# Altium schematic sheet PowerSupply.SchDoc(PowerSupply)
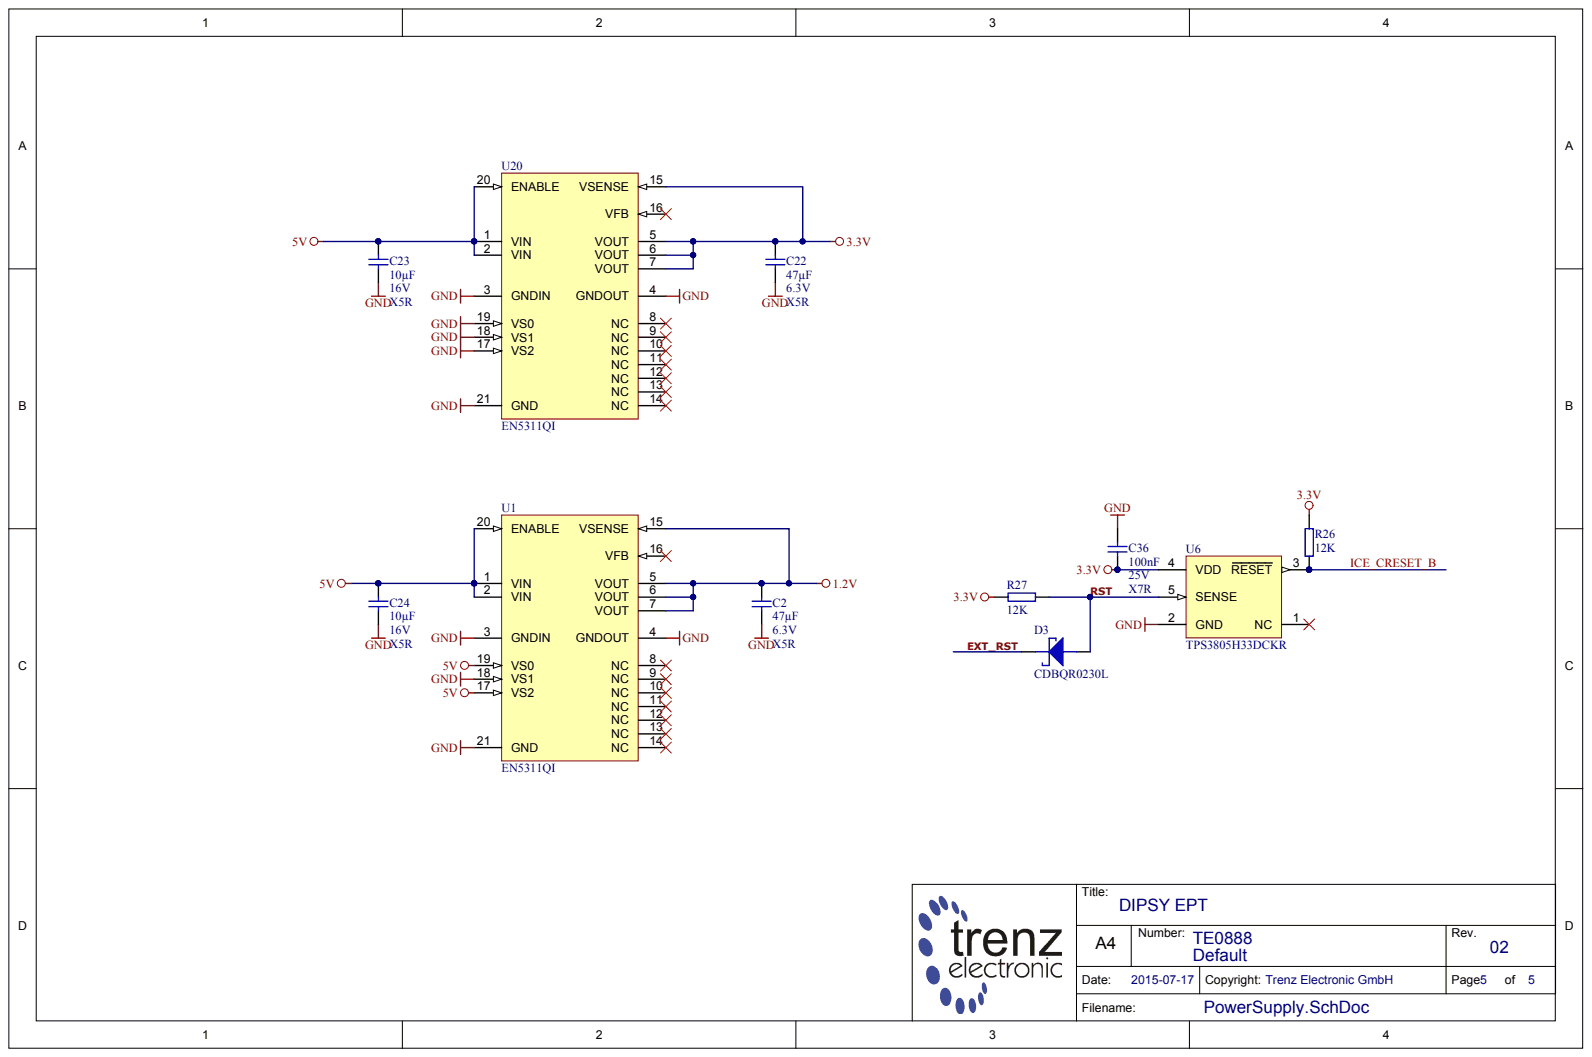
[diagram p0: PowerSupply.SchDoc]

## Parts
C2  47µF  Technology: X5R  Voltage: 6.3V  [CAPC2012X135N]
C22  47µF  Technology: X5R  Voltage: 6.3V  [CAPC2012X135N]
C23  10µF  Technology: X5R  Voltage: 16V  [CAPC1608X90N]
C24  10µF  Technology: X5R  Voltage: 16V  [CAPC1608X90N]
C36  100nF  Voltage: 25V  [CAPC1005X55N]
D3  CDBQR0230L  [DIOC1006X55N]
R26  12K  [RESC1005X40N]
R27  12K  [RESC1005X40N]
U1  EN5311QI  [EN5311QI]
U6  TPS3805H33DCKR  [SOT65P210X110-5N]
U20  EN5311QI  [EN5311QI]

## Nets
1.2V: C2.2 U1.5(VOUT) U1.6(VOUT) U1.7(VOUT) U1.15(VSENSE)
3.3V: C22.2 C36.2 R26.2 R27.2 U6.4(VDD) U20.5(VOUT) U20.6(VOUT) U20.7(VOUT) U20.15(VSENSE)
5V: C23.1 C24.1 U1.1(VIN) U1.2(VIN) U1.17(VS2) U1.19(VS0) U1.20(ENABLE) U20.1(VIN) U20.2(VIN) U20.20(ENABLE)
GND: C2.1 C22.1 C23.2 C24.2 C36.1 U1.3(GNDIN) U1.4(GNDOUT) U1.18(VS1) U1.21(GND) U6.2(GND) U20.3(GNDIN) U20.4(GNDOUT) U20.17(VS2) U20.18(VS1) U20.19(VS0) U20.21(GND)
EXT_RST: D3.1
ICE_CRESET_B: R26.1 U6.3(RESET)
RST: D3.2 R27.1 U6.5(SENSE)

Not connected: U1 8(NC) 9(NC) 10(NC) 11(NC) 12(NC) 13(NC) 14(NC) 16(VFB); U6 1(NC); U20 8(NC) 9(NC) 10(NC) 11(NC) 12(NC) 13(NC) 14(NC) 16(VFB)

## Library data of the parts
C2 C22 = 24718: KON 47µF 6,3V  X5R SMD 20%; Database Table Name: C_2012_0805; Mixed: SMT; Preferred: Yes; Value: 47u; AN: 24718; Case: 2012 (0805); Component Kind: Standard; Library Name: TE.DbLib; Tolerance: 20%
C23 C24 = 25341: KON 10µF 16V X5R SMD 20%; Database Table Name: C_1608_0603; Mixed: SMT; Preferred: Yes; Value: 10u; AN: 25341; Case: 1608 (0603); Component Kind: Standard; Library Name: TE.DbLib; Tolerance: 20%
C36 = 22472: KON 100nF 25V X5R SMD; Database Table Name: C_1005_0402; Mixed: SMT; Preferred: Yes; Value: 100n; AN: 22472; Case: 1005 (0402); Component Kind: Standard; Library Name: TE.DbLib; Technology: X7R
D3 = 26726: Database Table Name: DIODE; Mixed: SMT; Value: 30V; Voltage: 0.2A; AN: 26726; Case: SOD-923F (0402); Component Kind: Standard; Description: CDBQR0230L; Library Name: TE.DbLib; HelpURL: B:\ad\adlib\datasheet\CDBQR0230L
R26 R27 = 22598: WID SMD 12K 1% 0,063W; Database Table Name: R_1005_0402; Mixed: SMT; Preferred: Yes; AN: 22598; Case: 1005 (0402); Component Kind: Standard; Library Name: TE.DbLib; Value: 12K
U1 U20 = 25492: Database Table Name: DCDC; Mixed: SMT; Preferred: Yes; AN: 25492; Case: QFN20; Component Kind: Standard; Description: EN5311QI; Library Name: TE.DbLib; Trenz-Stock: Yes; HelpURL: B:\AD\adlib\datasheet\EN5311QI
U6 = 25049: Database Table Name: SUPERVISOR; Mixed: SMT; Preferred: Yes; AN: 25049; Case: SC70-5 / SC88A / SOT353; Component Kind: Standard; Description: TPS3805H33DCKR; Library Name: TE.DbLib; Trenz-Stock: Yes; HelpURL: B:\AD\adlib\datasheet\TPS3805H33
